# Altium schematic sheet TOP Sheet.SchDoc
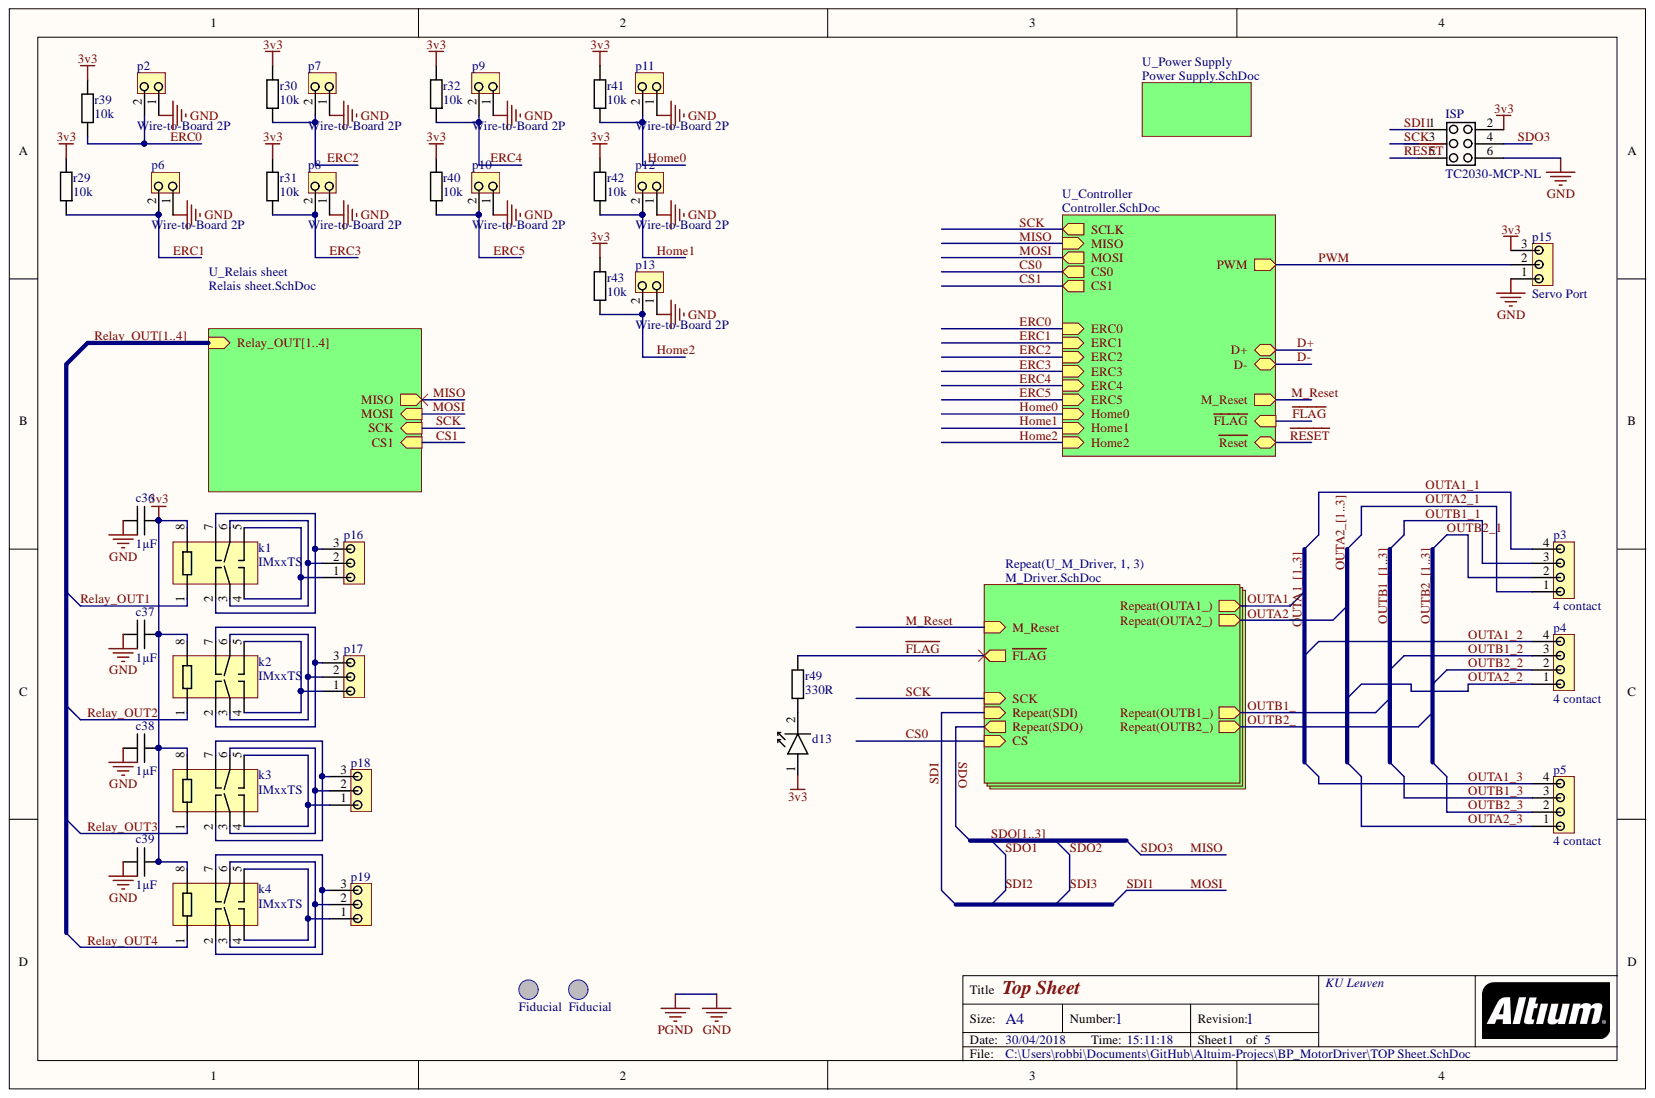
[diagram p0: TOP Sheet.SchDoc]

## Parts
c36  1µF  [0805]
c37  1µF  [0805]
c38  1µF  [0805]
c39  1µF  [0805]
d13  Yellow SMD LED  [0603 - LED]  (value not drawn)
f3  Fiducial  [Fiducial]
f4  Fiducial  [Fiducial]
ISP  TC2030-MCP-NL  [2x3-HeaderPin]
k1  IMxxTS  [THT-8]
k2  IMxxTS  [THT-8]
k3  IMxxTS  [THT-8]
k4  IMxxTS  [THT-8]
p2  Wire-to-Board 2P  [WtB-F-2p_V]
p3  4 contact  [WtB-F-4p]
p4  4 contact  [WtB-F-4p]
p5  4 contact  [WtB-F-4p]
p6  Wire-to-Board 2P  [WtB-F-2p_V]
p7  Wire-to-Board 2P  [WtB-F-2p_V]
p8  Wire-to-Board 2P  [WtB-F-2p_V]
p9  Wire-to-Board 2P  [WtB-F-2p_V]
p10  Wire-to-Board 2P  [WtB-F-2p_V]
p11  Wire-to-Board 2P  [WtB-F-2p_V]
p12  Wire-to-Board 2P  [WtB-F-2p_V]
p13  Wire-to-Board 2P  [WtB-F-2p_V]
p15  Servo Port  [1X3 Headerpin Angled]
p16  Wire-to-Board 3P  [1X3 Headerpin Angled]  (value not drawn)
p17  Wire-to-Board 3P  [1X3 Headerpin Angled]  (value not drawn)
p18  Wire-to-Board 3P  [1X3 Headerpin Angled]  (value not drawn)
p19  Wire-to-Board 3P  [1X3 Headerpin Angled]  (value not drawn)
r29  10k  [0603]
r30  10k  [0603]
r31  10k  [0603]
r32  10k  [0603]
r39  10k  [0603]
r40  10k  [0603]
r41  10k  [0603]
r42  10k  [0603]
r43  10k  [0603]
r49  330R  [0603]

## Nets
3v3: c36.2 c37.2 c38.2 c39.2 d13.1 ISP.2 k1.8 k2.8 k3.8 k4.8 p15.3 r29.2 r30.2 r31.2 r32.2 r39.2 r40.2 r41.2 r42.2 r43.2
GND: c36.1 c37.1 c38.1 c39.1 ISP.6 p2.1 p6.1 p7.1 p8.1 p9.1 p10.1 p11.1 p12.1 p13.1 p15.1
ERC0: p2.2 r39.1
ERC1: p6.2 r29.1
ERC2: p7.2 r30.1
ERC3: p8.2 r31.1
ERC4: p9.2 r32.1
ERC5: p10.2 r40.1
~{FLAG}: r49.2
Home0: p11.2 r41.1
Home1: p12.2 r42.1
Home2: p13.2 r43.1
MOSI: ISP.1
OUTA1_1: p3.4  [also labelled OUTA1_]
OUTA1_2: p4.4  [also labelled OUTA1_]
OUTA1_3: p5.4  [also labelled OUTA1_]
OUTA2_1: p3.1  [also labelled OUTA2_]
OUTA2_2: p4.1  [also labelled OUTA2_]
OUTA2_3: p5.1  [also labelled OUTA2_]
OUTB1_1: p3.3  [also labelled OUTB1_]
OUTB1_2: p4.3  [also labelled OUTB1_]
OUTB1_3: p5.3  [also labelled OUTB1_]
OUTB2_1: p3.2  [also labelled OUTB2_]
OUTB2_2: p4.2  [also labelled OUTB2_]
OUTB2_3: p5.2  [also labelled OUTB2_]
PWM: p15.2
~{RESET}: ISP.5
Relay_OUT1: k1.1  [also labelled RELAY_OUT]
Relay_OUT2: k2.1  [also labelled RELAY_OUT]
Relay_OUT3: k3.1  [also labelled RELAY_OUT]
Relay_OUT4: k4.1  [also labelled RELAY_OUT]
SCK: ISP.3
Netd13_2: d13.2 r49.1
Netk1_2: k1.2 k1.7 p16.3
Netk1_3: k1.3 k1.6 p16.2
Netk1_4: k1.4 k1.5 p16.1
Netk2_2: k2.2 k2.7 p17.3
Netk2_3: k2.3 k2.6 p17.2
Netk2_4: k2.4 k2.5 p17.1
Netk3_2: k3.2 k3.7 p18.3
Netk3_3: k3.3 k3.6 p18.2
Netk3_4: k3.4 k3.5 p18.1
Netk4_2: k4.2 k4.7 p19.3
Netk4_3: k4.3 k4.6 p19.2
Netk4_4: k4.4 k4.5 p19.1
CS0:
CS1:
D+:
D-:
M_Reset:
N00106:
SDO[1..3]:

Not connected: ISP 4

## Library data of the parts
c36-c39 = 0805 Capacitor: ...F; Component Kind: Standard; Library Name: Generic R-C.SchLib
d13 = Yellow SMD LED: 0603 Package; Component Kind: Standard; Library Name: Kingbright.SchLib
ISP = 2x3 Header: Header Pin; Component Kind: Standard; Library Name: Multicomp.SchLib
k1-k4 = IMxxTS: Standard THT Package; Component Kind: Standard; Library Name: TE Connectivity.SchLib
p3-p5 = Wire-to-Board connector 4 contacts: Wire-to-Board connector; Component Kind: Standard; Library Name: Wurth Electronic.SchLib
p15 = Wire-to-Board 3 Pin: Component Kind: Standard; Library Name: Wurth Electronic.SchLib
r29-r32 r39-r43 r49 = 0603 Resistor: ...R; Component Kind: Standard; Library Name: Generic R-C.SchLib
f3 f4 = Fiducial: Component Kind: Standard; Description: Fiducial; Library Name: Board_Props.SchLib
p2 p6-p13 = Wire-to-Board 2P: Component Kind: Standard; Library Name: Wurth Electronic.SchLib
p16-p19 = Wire-to-Board 3P: Component Kind: Standard; Library Name: Wurth Electronic.SchLib
